# Altium schematic sheet 01micro.SchDoc(01micro)
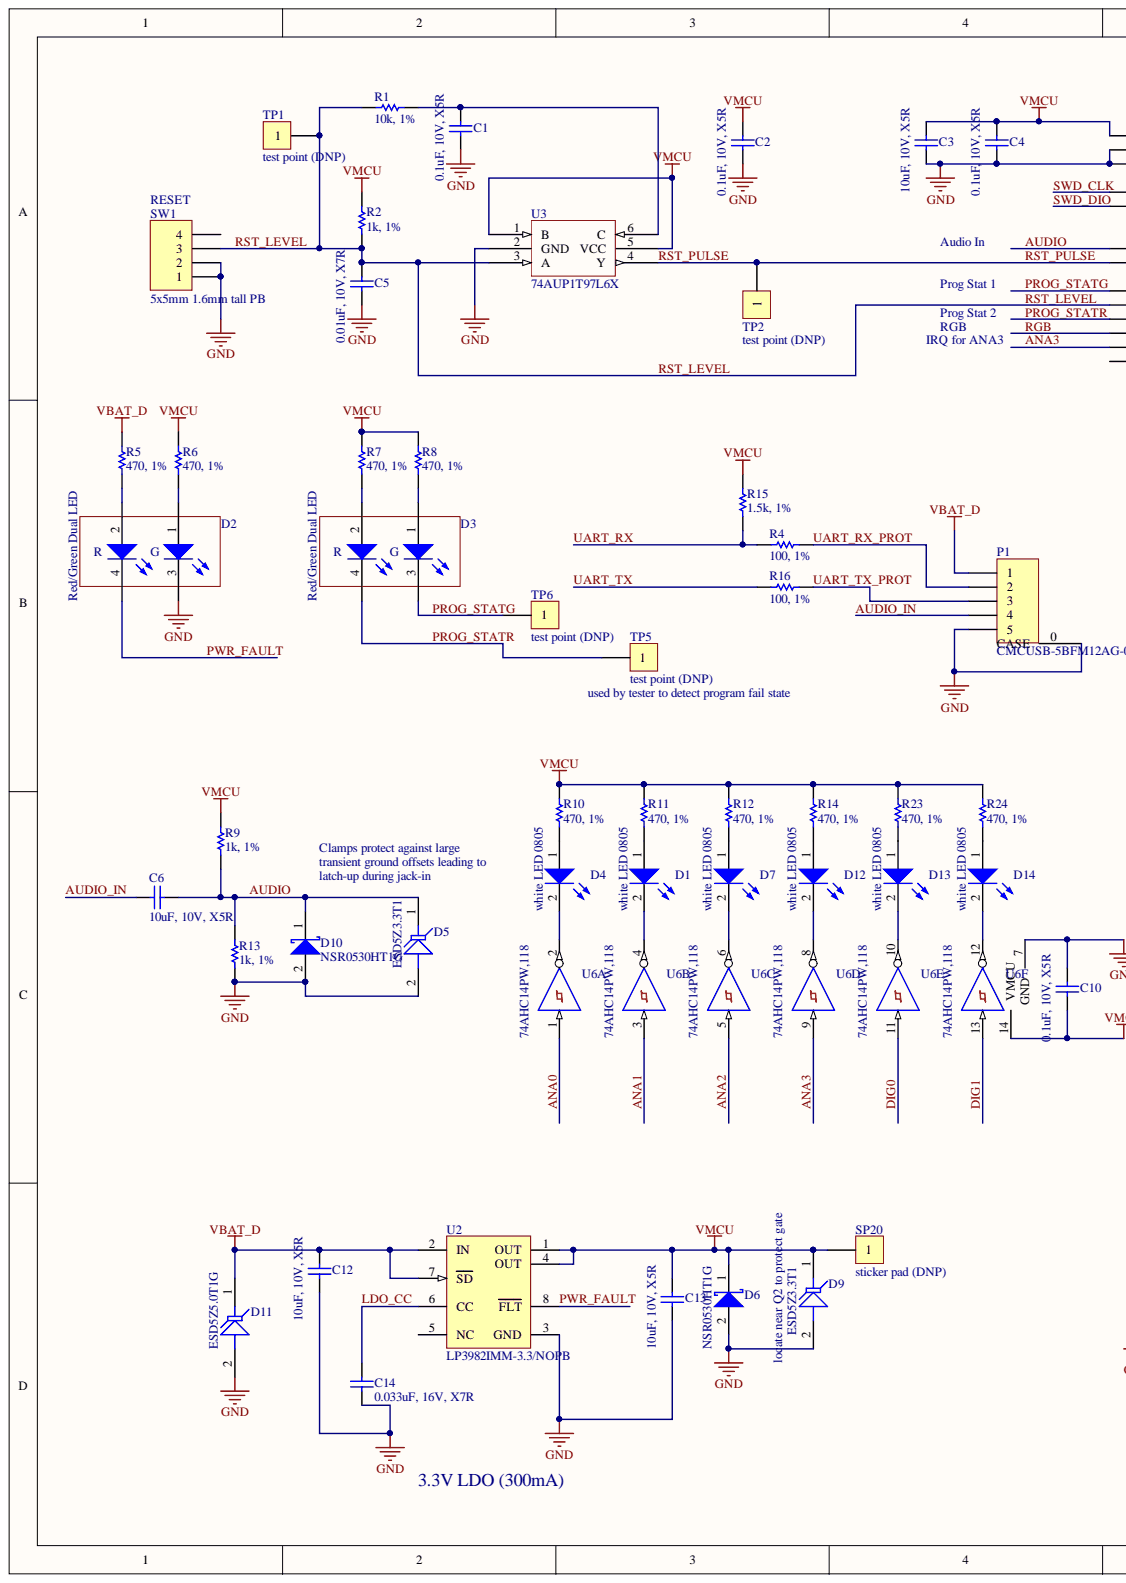
[diagram p0: 01micro.SchDoc, left]
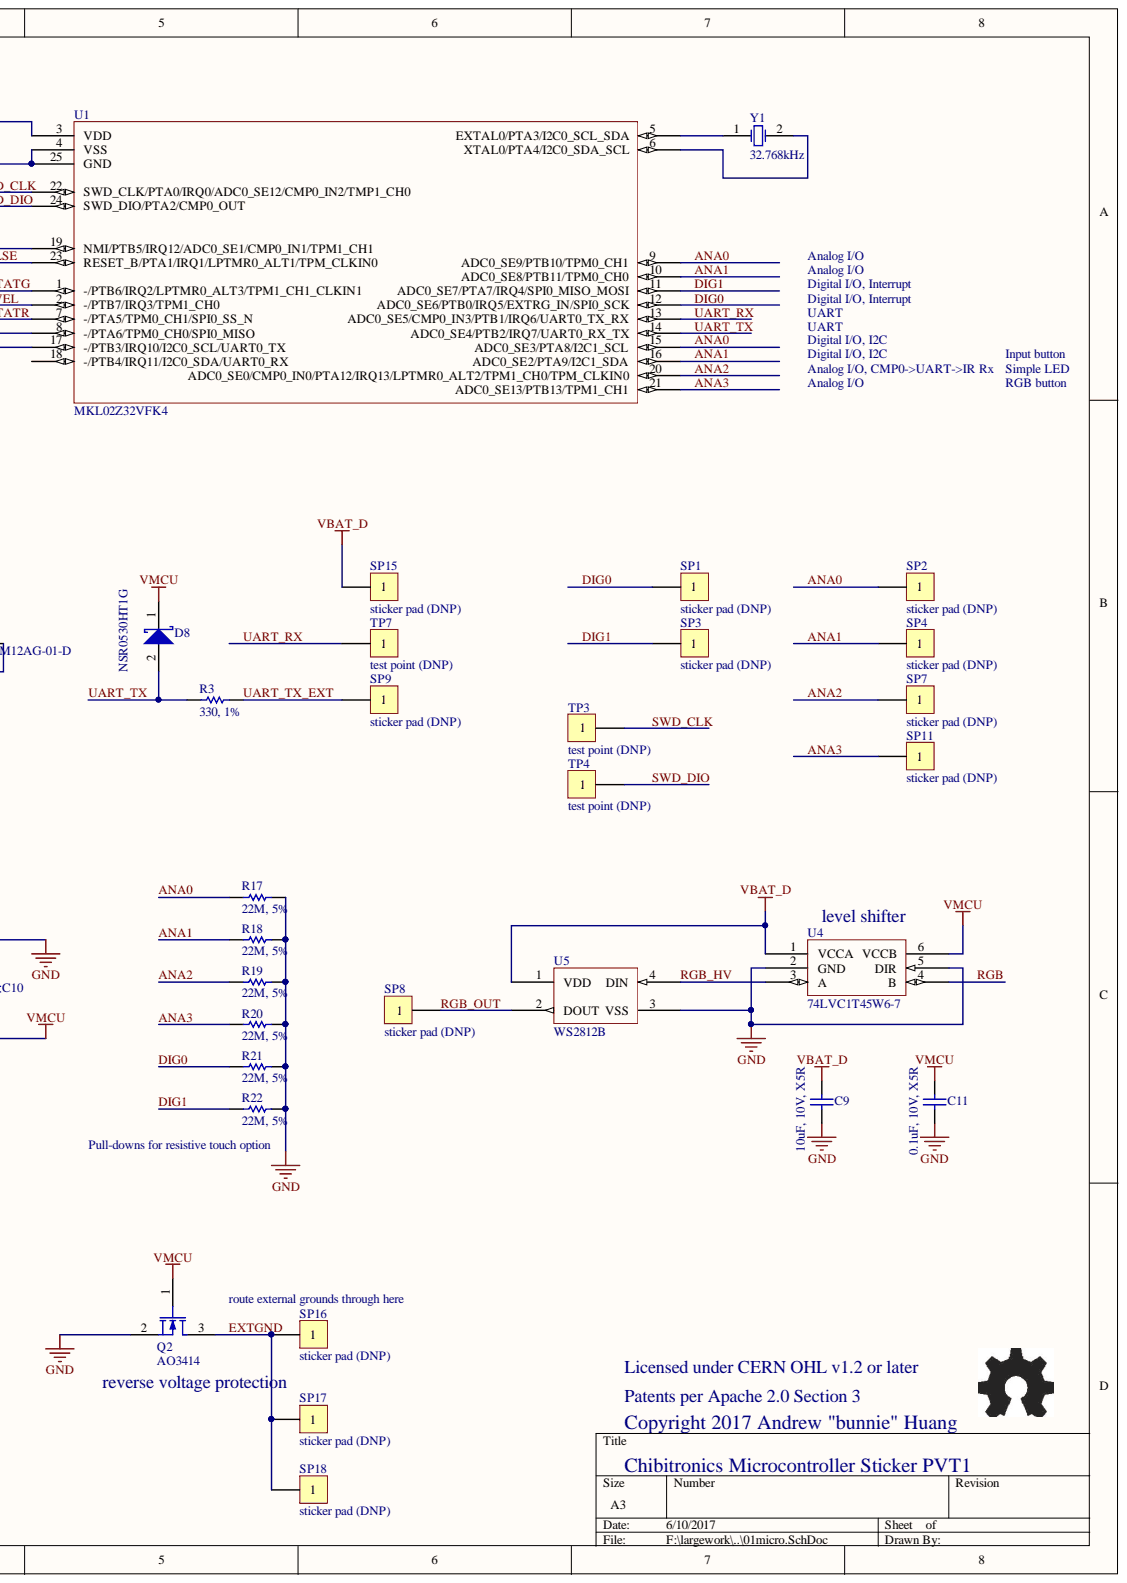
[diagram p1: 01micro.SchDoc, right]

## Parts
C1  0.1uF, 10V, X5R  [CAPC1005N]
C2  0.1uF, 10V, X5R  [CAPC1005N]
C3  10uF, 10V, X5R  [CAPC2012N]
C4  0.1uF, 10V, X5R  [CAPC1005N]
C5  0.01uF, 10V, X7R  [CAPC1005N]
C6  10uF, 10V, X5R  [CAPC2012N]
C9  10uF, 10V, X5R  [CAPC2012N]
C10  0.1uF, 10V, X5R  [CAPC1005N]
C11  0.1uF, 10V, X5R  [CAPC1005N]
C12  10uF, 10V, X5R  [CAPC2012N]
C13  10uF, 10V, X5R  [CAPC2012N]
C14  0.033uF, 16V, X7R  [CAPC1005N]
D1  white LED 0805  [CD2012-0805]
D2  Red/Green Dual LED  [LED_1210_DUAL]
D3  Red/Green Dual LED  [LED_1210_DUAL]
D4  white LED 0805  [CD2012-0805]
D5  ESD5Z3.3T1  [SOD-523]
D6  NSR0530HT1G  [SOD-323]
D7  white LED 0805  [CD2012-0805]
D8  NSR0530HT1G  [SOD-323]
D9  ESD5Z3.3T1  [SOD-523]
D10  NSR0530HT1G  [SOD-323]
D11  ESD5Z5.0T1G  [SOD-523]
D12  white LED 0805  [CD2012-0805]
D13  white LED 0805  [CD2012-0805]
D14  white LED 0805  [CD2012-0805]
P1  CMCUSB-5BFM12AG-01-D  [FCI_10103593]
Q2  AO3414  [SOT23-3N]
R1  10k, 1%  [RESC1005N]
R2  1k, 1%  [RESC1005N]
R3  330, 1%  [RESC1005N]
R4  100, 1%  [RESC1005N]
R5  470, 1%  [RESC1005N]
R6  470, 1%  [RESC1005N]
R7  470, 1%  [RESC1005N]
R8  470, 1%  [RESC1005N]
R9  1k, 1%  [RESC1005N]
R10  470, 1%  [RESC1005N]
R11  470, 1%  [RESC1005N]
R12  470, 1%  [RESC1005N]
R13  1k, 1%  [RESC1005N]
R14  470, 1%  [RESC1005N]
R15  1.5k, 1%  [RESC1005N]
R16  100, 1%  [RESC1005N]
R17  22M, 5%  [RESC1608N]
R18  22M, 5%  [RESC1608N]
R19  22M, 5%  [RESC1608N]
R20  22M, 5%  [RESC1608N]
R21  22M, 5%  [RESC1608N]
R22  22M, 5%  [RESC1608N]
R23  470, 1%  [RESC1005N]
R24  470, 1%  [RESC1005N]
SP1  sticker pad (DNP)  [TP_2MM_SQ]
SP2  sticker pad (DNP)  [TP_2MM_SQ]
SP3  sticker pad (DNP)  [TP_2MM_SQ]
SP4  sticker pad (DNP)  [TP_2MM_SQ]
SP7  sticker pad (DNP)  [TP_2MM_SQ]
SP8  sticker pad (DNP)  [TP_2MM_SQ]
SP9  sticker pad (DNP)  [CHIBI-MICRO-PAD-MIN-PLACEHOLDER]
SP11  sticker pad (DNP)  [TP_2MM_SQ]
SP15  sticker pad (DNP)  [CHIBI-MICRO-PAD-MIN-PLACEHOLDER]
SP16  sticker pad (DNP)  [TP_2MM_SQ]
SP17  sticker pad (DNP)  [TP_2MM_SQ]
SP18  sticker pad (DNP)  [TP_2MM_SQ]
SP20  sticker pad (DNP)  [TP_2MM_SQ]
SW1  5x5mm 1.6mm tall PB  [SWITCH_TS-1187A]
TP1  test point (DNP)  [TP_2MM_SQ]
TP2  test point (DNP)  [TP_2MM_SQ]
TP3  test point (DNP)  [TP_2MM_SQ]
TP4  test point (DNP)  [TP_2MM_SQ]
TP5  test point (DNP)  [TP_2MM_SQ]
TP6  test point (DNP)  [TP_2MM_SQ]
TP7  test point (DNP)  [TP_2MM_SQ]
U1  MKL02Z32VFK4  [QFN50P400X400X100_HS-25N]
U2  LP3982IMM-3.3/NOPB  [MUA08A_N]
U3  74AUP1T97L6X  [FSC-L6X-PSON50P100X55-6N]
U4  74LVC1T45W6-7  [SOT26A-6N]
U5  WS2812B  [WS2812B]
U6  74AHC14PW,118  [948G-01_N]  (parts A,B,C,D,E,F)
Y1  32.768kHz  [ABS07-120]

## Nets
GND: C1.2 C2.2 C3.2 C4.2 C5.2 C9.2 C10.1 C11.2 C12.2 C13.2 C14.1 D2.3 D5.2 D6.2 D9.2 D10.2 D11.2 P1.0(CASE) P1.5 Q2.2 R13.2 R17.2 R18.2 R19.2 R20.2 R21.2 R22.2 SW1.1 SW1.2 U1.4(VSS) U1.25(GND) U2.3(GND) U3.2(GND) U4.2(GND) U4.5(DIR) U5.3(VSS) U6.7(GND)
VBAT_D: C9.1 C12.1 D11.1 P1.1 R5.1 SP15.1 U2.2(IN) U2.7(SD) U4.1(VCCA) U5.1(VDD)
VDD: U6.14(VMCU)
VMCU: C2.1 C3.1 C4.1 C10.2 C11.1 C13.1 D6.1 D8.1 D9.1 Q2.1 R2.1 R6.1 R7.1 R8.1 R9.1 R10.1 R11.1 R12.1 R14.1 R15.1 R23.1 R24.1 SP20.1 U1.3(VDD) U2.1(OUT) U2.4(OUT) U3.1(B) U3.5(VCC) U4.6(VCCB) U6.14(VMCU)
ANA0: R17.1 SP2.1 U1.9(ADC0_SE9/PTB10/TPM0_CH1) U1.15(ADC0_SE3/PTA8/I2C1_SCL) U6.1
ANA1: R18.1 SP4.1 U1.10(ADC0_SE8/PTB11/TPM0_CH0) U1.16(ADC0_SE2/PTA9/I2C1_SDA) U6.3
ANA2: R19.1 SP7.1 U1.20(ADC0_SE0/CMP0_IN0/PTA12/IRQ13/LPTMR0_ALT2/TPM1_CH0/TPM_CLKIN0) U6.5
ANA3: R20.1 SP11.1 U1.17(-/PTB3/IRQ10/I2C0_SCL/UART0_TX) U1.21(ADC0_SE13/PTB13/TPM1_CH1) U6.9
AUDIO: C6.2 D5.1 D10.1 R9.2 R13.1 U1.19(NMI/PTB5/IRQ12/ADC0_SE1/CMP0_IN1/TPM1_CH1)
AUDIO_IN: C6.1 P1.4
DIG0: R21.1 SP1.1 U1.12(ADC0_SE6/PTB0/IRQ5/EXTRG_IN/SPI0_SCK) U6.11
DIG1: R22.1 SP3.1 U1.11(ADC0_SE7/PTA7/IRQ4/SPI0_MISO_MOSI) U6.13
EXTGND: Q2.3 SP16.1 SP17.1 SP18.1
LDO_CC: C14.2 U2.6(CC)
PROG_STATG: D3.3 TP6.1 U1.1(-/PTB6/IRQ2/LPTMR0_ALT3/TPM1_CH1_CLKIN1)
PROG_STATR: D3.4 TP5.1 U1.7(-/PTA5/TPM0_CH1/SPI0_SS_N)
PWR_FAULT: D2.4 U2.8(FLT)
RGB: U1.8(-/PTA6/TPM0_CH0/SPI0_MISO) U4.4(B)
RGB_HV: U4.3(A) U5.4(DIN)
RGB_OUT: SP8.1 U5.2(DOUT)
RST_LEVEL: C5.1 R1.2 R2.2 SW1.3 TP1.1 U1.2(-/PTB7/IRQ3/TPM1_CH0) U3.3(A)
RST_PULSE: TP2.1 U1.23(RESET_B/PTA1/IRQ1/LPTMR0_ALT1/TPM_CLKIN0) U3.4(Y)
SWD_CLK: TP3.1 U1.22(SWD_CLK/PTA0/IRQ0/ADC0_SE12/CMP0_IN2/TMP1_CH0)
SWD_DIO: TP4.1 U1.24(SWD_DIO/PTA2/CMP0_OUT)
UART_RX: R4.1 R15.2 TP7.1 U1.13(ADC0_SE5/CMP0_IN3/PTB1/IRQ6/UART0_TX_RX)
UART_RX_PROT: P1.2 R4.2
UART_TX: D8.2 R3.1 R16.1 U1.14(ADC0_SE4/PTB2/IRQ7/UART0_RX_TX)
UART_TX_EXT: R3.2 SP9.1
UART_TX_PROT: P1.3 R16.2
NetC1_1: C1.1 R1.1 U3.6(C)
NetD1_1: D1.1 R11.2
NetD1_2: D1.2 U6.4
NetD2_1: D2.1 R6.2
NetD2_2: D2.2 R5.2
NetD3_1: D3.1 R8.2
NetD3_2: D3.2 R7.2
NetD4_1: D4.1 R10.2
NetD4_2: D4.2 U6.2
NetD7_1: D7.1 R12.2
NetD7_2: D7.2 U6.6
NetD12_1: D12.1 R14.2
NetD12_2: D12.2 U6.8
NetD13_1: D13.1 R23.2
NetD13_2: D13.2 U6.10
NetD14_1: D14.1 R24.2
NetD14_2: D14.2 U6.12
NetU1_5: U1.5(EXTAL0/PTA3/I2C0_SCL_SDA) Y1.1
NetU1_6: U1.6(XTAL0/PTA4/I2C0_SDA_SCL) Y1.2

Not connected: SW1 4; U1 18(-/PTB4/IRQ11/I2C0_SDA/UART0_RX); U2 5(NC)

## Library data of the parts
C1 C2 C4 C10 C11 = Cap Semi: Capacitor (Semiconductor SIM Model); MPN: CL05A104KP5NNNC; VENDOR: Samsung; MYPN: C-104-10-X5R-0402; Simulation: CAP; Signal Integrity: Cap Semi; Library Name: Miscellaneous Devices.IntLib; Component Kind: Standard
C3 C6 C9 C12 C13 = Cap Semi: Capacitor (Semiconductor SIM Model); MPN: CL21A106KPCLQNC; VENDOR: Samsung; MYPN: C-106-10-X5R-0805; Simulation: CAP; Signal Integrity: Cap Semi; Library Name: Miscellaneous Devices.IntLib; Component Kind: Standard
C5 = Cap Semi: Capacitor (Semiconductor SIM Model); MPN: CL05B103KP5NNNC; VENDOR: Samsung; MYPN: C-103-10-X7R-0402; Simulation: CAP; Signal Integrity: Cap Semi; Library Name: Miscellaneous Devices.IntLib; Component Kind: Standard
C14 = Cap Semi: Capacitor (Semiconductor SIM Model); MPN: CL05B333KO5NNNC; VENDOR: Samsung; MYPN: C-333-16-X7R-0402; Simulation: CAP; Signal Integrity: Cap Semi; Library Name: Miscellaneous Devices.IntLib; Component Kind: Standard
D1 D4 D7 D12-D14 = LED2: White LED; MPN: CB-2012QWC-P8; VENDOR: Cheng Guang Xing; MYPN: D-LED-WHITE-0805; Simulation: LED2; Published: 8-Jun-2000; PackageVersion: Feb-2002; PackageReference: 3.2X1.6X1.1; Library Name: Miscellaneous Devices.IntLib; LatestRevisionNote: Re-released for DXP Platform.; LatestRevisionDate: 17-Jul-2002; Component Kind: Standard; Publisher: Altium Limited
D2 D3 = DUAL_LED_1210: 3.2mm x 2.7mm dual LED red/green; MPN: CB-3227QRQGC-PX; VENDOR: Citybright; MYPN: D-LED-1210-DUAL-RED-GREEN; Library Name: commonsch-new.SchLib; Component Kind: Standard
D5 D9 = Tranzorb: On Semi 3.3V standoff TVS diode; MPN: ESD5Z3.3T1G; VENDOR: On Semiconductor; MYPN: D-ESD5Z3.3T1; Published: 24-Mar-1999; PackageReference: DO-7; Library Name: Miscellaneous Devices.IntLib; LatestRevisionNote: Re-released for DXP Platform.; LatestRevisionDate: 17-Jul-2002; Component Kind: Standard; Publisher: Altium Limited
D6 D8 D10 = D Schottky: Schottky Diode; MPN: NSR0530H-D; VENDOR: Semtech HK LTD; MYPN: D-S-0p5-30-0p37-SOD323; Simulation: SKYDIODE; Signal Integrity: D Schottky; Revision: July-2002: Re-released for DXP Platform.; Library Name: Miscellaneous Devices.IntLib; Component Kind: Standard
D11 = Tranzorb: On Semi 5.0V standoff TVS diode; VENDOR: On Semiconductor; MYPN: D-ESD5Z5.0T1G; Published: 24-Mar-1999; PackageReference: DO-7; MPN: ESD5Z5.0T1G; Library Name: Miscellaneous Devices.IntLib; LatestRevisionNote: Re-released for DXP Platform.; LatestRevisionDate: 17-Jul-2002; Component Kind: Standard; Publisher: Altium Limited
P1 = Header 5: Micro USB B-type mid-mount; VENDOR: Kaweei; MYPN: J-MICRO-USB-MID-FCI; Signal Integrity: Connector; MPN: CMCUSB-5BFM12AG-01-D; Library Name: Miscellaneous Connectors.IntLib; LatestRevisionNote: Re-released for DXP Platform.; LatestRevisionDate: 17-Jul-2002; Component Kind: Standard; Publisher: Altium Limited
Q2 = MOSFET-N: N-Channel MOSFET; VENDOR: Alpha & Omega Semiconductor; MYPN: Q-AO3414; Simulation: NMOS; Published: 8-Jun-2000; PackageVersion: 1995/1996; PackageReference: E3; MPN: AO3414; Library Name: Miscellaneous Devices.IntLib; LatestRevisionNote: Re-released for DXP Platform.; LatestRevisionDate: 17-Jul-2002; Component Kind: Standard; Publisher: Altium Limited
R1 = Res1: Resistor; MPN: RS02U103FT; VENDOR: Fenghua; MYPN: R-103-1P-1_16W-0402; Simulation: RESISTOR; Signal Integrity: Res; Library Name: Miscellaneous Devices.IntLib; Component Kind: Standard
R2 R9 = Res1: Resistor; MPN: RS02U102FT; VENDOR: Fenghua; MYPN: R-102-1P-1_16W-0402; Simulation: RESISTOR; Signal Integrity: Res; Library Name: Miscellaneous Devices.IntLib; Component Kind: Standard
R3 = Res1: Resistor; MPN: RS02U331FT; VENDOR: Fenghua; MYPN: R-331-1P-1_16W-0402; Simulation: RESISTOR; Signal Integrity: Res; Library Name: Miscellaneous Devices.IntLib; Component Kind: Standard
R4 R16 = Res1: Resistor; MPN: RS02U101FT; VENDOR: Fenghua; MYPN: R-101-1P-1_16W-0402; Simulation: RESISTOR; Signal Integrity: Res; Library Name: Miscellaneous Devices.IntLib; Component Kind: Standard
R5-R8 R10-R12 R14 R23 R24 = Res1: Resistor; MPN: RS02U471FT; VENDOR: Fenghua; MYPN: R-471-1P-1_16W-0402; Simulation: RESISTOR; Signal Integrity: Res; Library Name: Miscellaneous Devices.IntLib; Component Kind: Standard
R13 = Res1: Resistor; MPN: RS02U1021FT; VENDOR: Fenghua; MYPN: R-102-1P-1_16W-0402; Simulation: RESISTOR; Signal Integrity: Res; Library Name: Miscellaneous Devices.IntLib; Component Kind: Standard
R15 = Res1: Resistor; MPN: RS02U152FT; VENDOR: Fenghua; MYPN: R-152-1P-1_16W-0402; Simulation: RESISTOR; Signal Integrity: Res; Library Name: Miscellaneous Devices.IntLib; Component Kind: Standard
R17-R22 = Res1: Resistor; MPN: RS03U226FT; VENDOR: Fenghua; MYPN: R-226-1P-1_16W-0603; Simulation: RESISTOR; Signal Integrity: Res; Library Name: Miscellaneous Devices.IntLib; Component Kind: Standard
SP1-SP4 SP7-SP9 SP11 SP15-SP18 SP20 TP1-TP7 = CON1: Connector; Component Kind: Standard
SW1 = Header 4: Chi Fung TS-1187A; VENDOR: Kangdeli; MYPN: SW-PB-5X5-1.6; Signal Integrity: Connector; MPN: SW-PB-5X5-1.6; Library Name: Miscellaneous Connectors.IntLib; LatestRevisionNote: Re-released for DXP Platform.; LatestRevisionDate: 17-Jul-2002; Component Kind: Standard; Publisher: Altium Limited
U1 = MKL02Z32VFK4: Kinetis KL02 32 KB Flash, 48MHz Cortex-M0+; VENDOR: NXP; MYPN: UMKL02Z32VFK4; MPN: MKL02Z32VFK4; Library Name: commonsch-new.SchLib; Component Kind: Standard
U2 = LP3982IMM-3.3/NOPB: Micropower, Ultra Low-Dropout, Low-Noise, 300mA CMOS Regulator, 8-pin Mini SOIC, Pb-Free; Manufacturer: National Semiconductor; VENDOR: TI; PowerWise: N; PackageTopMarking: ZXTT*LEUB; MYPN: ULP3982IMM-3.3/NOPB; High-Reliability: Y; CompType: LDO IC; RoHS: Y; PinCount: 8; PartNumber: LP3982IMM-3.3/NOPB; PackageVersion: revF, Apr-2008; PackageReference: MUA08A; PackageDescription: MINI SOIC, .118 x .118 x .034in, 8 Lead, .0256in Pitch; MPN: LP3982IMM-3.3/NOPB; Library Name: National Semiconductor LDO.IntLib; DatasheetVersion: Mar-2006; ComponentLink4URL: http://www.national.com/packaging/folders/mua08a.html; ComponentLink4Description: MUA08A Package Page; ComponentLink3URL: http://www.national.com/pf/LP/LP3982.html; ComponentLink3Description: LP3982 Web Page; ComponentLink2URL: http://www.national.com/ds/LP/LP3982.pdf; ComponentLink2Description: LP3982 Datasheet; ComponentLink1URL: http://www.national.com/; ComponentLink1Description: Manufacturer URL; Component Kind: Standard; Code_JEDEC: MO-187-AA
U3 = 74AUP1T97L6X: Low power configurable gate with voltage translator; VENDOR: Fairchild Semiconductor; MYPN: U74AUP1T97; MPN: 74AUP1T97L6X; Library Name: commonsch-new.SchLib; Component Kind: Standard
U4 = SN74LVC1T45: Single-gate level translator; VENDOR: Diodes Incorporated; MYPN: USN74LVC1T45DBV; MPN: 74LVC1T45W6-7; Library Name: commonsch.lib; Component Kind: Standard
U5 = WS2812B: RGB LED with integrated controller IC; VENDOR: Worldsemi; MYPN: UWS2812B; MPN: WS2812B; Library Name: commonsch.lib; Component Kind: Standard
U6 = MC74HC14ADTR2: Hex Schmitt-Trigger Inverter; VENDOR: NXP; MYPN: U-74HC14TSSOP; Signal Integrity: MC74HC14ADTR2; Published: 15-Apr-2002; PackageVersion: 1998; PackageReference: 948G-01; PackageDescription: 14-Pin Thin Small Outline Package 0.65 mm Pitch; MPN: 74AHC14PW,118; Library Name: ON Semi Logic Buffer Line Driver.IntLib; LatestRevisionNote: IPC-7351 Footprint Added.; LatestRevisionDate: 12-Dec-2006; DatasheetVersion: Mar-2000; ComponentLink2URL: http://www.onsemi.com/pub/Collateral/MC74HC14A-D.PDF; ComponentLink2Description: Datasheet; ComponentLink1URL: http://www.onsemi.com/; ComponentLink1Description: Manufacturer Link; Component Kind: Standard; Publisher: Altium Limited
Y1 = XTAL: 3.2 x 1.5mm 32.768 kHz crystal, 6pF CL 70k ESR, 20ppm; MPN: SJFC1332K06F20; VENDOR: Songji; MYPN: X-32.768K-SONGJI; Simulation: XTAL; Library Name: Miscellaneous Devices.IntLib; Component Kind: Standard
